# Altium schematic sheet FTDI.SchDoc(FTDI)
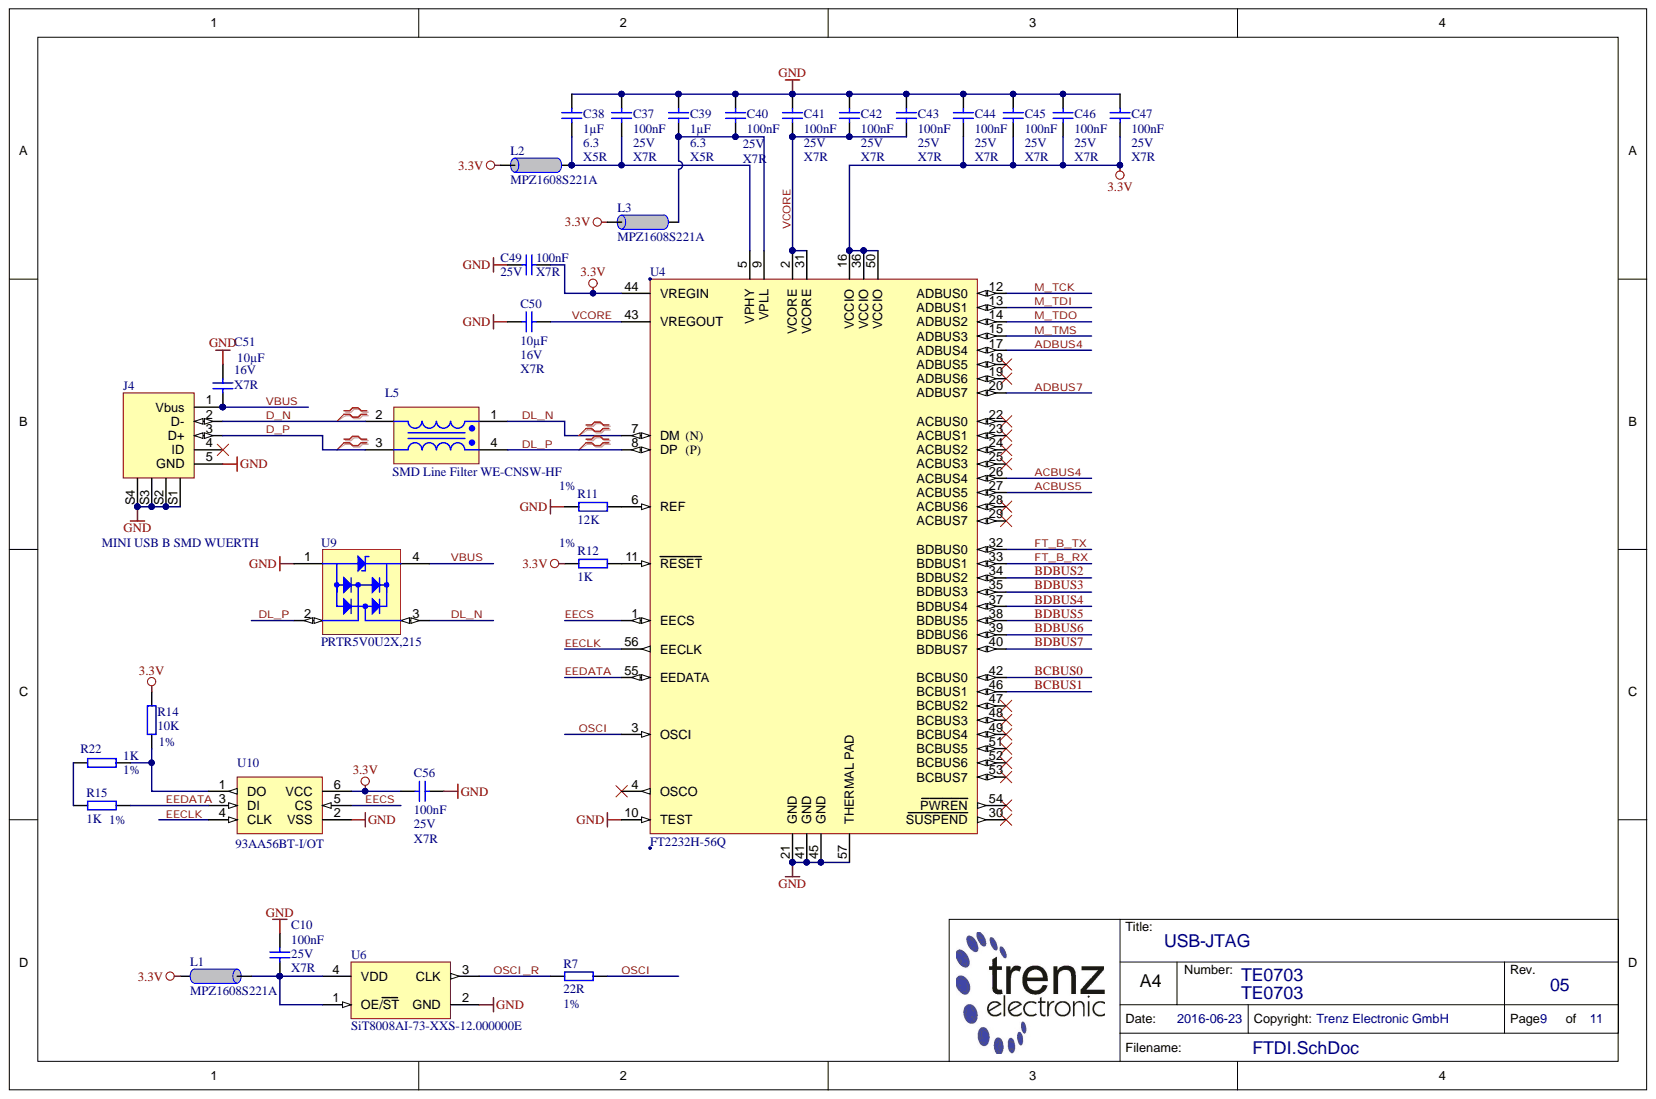
[diagram p0: FTDI.SchDoc]

## Parts
C10  100nF  Technology: X7R  Voltage: 25V  [CAPC1005X55N]
C37  100nF  Technology: X7R  Voltage: 25V  [CAPC1005X55N]
C38  1µF  Voltage: 6.3  [CAPC1005X55N]
C39  1µF  Technology: X5R  Voltage: 6.3  [CAPC1005X55N]
C40  100nF  Technology: X7R  Voltage: 25V  [CAPC1005X55N]
C41  100nF  Technology: X7R  Voltage: 25V  [CAPC1005X55N]
C42  100nF  Technology: X7R  Voltage: 25V  [CAPC1005X55N]
C43  100nF  Technology: X7R  Voltage: 25V  [CAPC1005X55N]
C44  100nF  Technology: X7R  Voltage: 25V  [CAPC1005X55N]
C45  100nF  Technology: X7R  Voltage: 25V  [CAPC1005X55N]
C46  100nF  Technology: X7R  Voltage: 25V  [CAPC1005X55N]
C47  100nF  Technology: X7R  Voltage: 25V  [CAPC1005X55N]
C49  100nF  Technology: X7R  Voltage: 25V  [CAPC1005X55N]
C50  10µF  Voltage: 16V  [CAPC2012X135N]
C51  10µF  Technology: X7R  Voltage: 16V  [CAPC2012X135N]
C56  100nF  Voltage: 25V  [CAPC1005X55N]
J4  MINI USB B SMD WUERTH  [MINI USB 5S B TYPE]
L1  MPZ1608S221A  [INDC1608X95N]
L2  MPZ1608S221A  [INDC1608X95N]
L3  MPZ1608S221A  [INDC1608X95N]
L5  SMD Line Filter WE-CNSW-HF  [WE-CNSW-HF]
R7  22R  Tolerance: 1%  [RESC1005X40N]
R11  12K  Tolerance: 1%  [RESC1005X40N]
R12  1K  Tolerance: 1%  [RESC1005X40N]
R14  10K  Tolerance: 1%  [RESC1005X40N]
R15  1K  Tolerance: 1%  [RESC1005X40N]
R22  1K  Tolerance: 1%  [RESC1005X40N]
U4  FT2232H-56Q  [QFN50P800X800-57T610X610N]
U6  SiT8008AI-73-XXS-12.000000E  Database Table Name: CLK  [2016]
U9  PRTR5V0U2X,215  [SOT190P229X114-4N]
U10  93AA56BT-I/OT  [SOT95P280X145-6N]

## Nets
3.3V: C44.2 C45.2 C46.2 C47.2 C49.2 C56.1 L1.1 L2.2 L3.2 R12.1 R14.1 U4.16(VCCIO) U4.36(VCCIO) U4.44(VREGIN) U4.50(VCCIO) U10.6(VCC)
GND: C10.2 C37.1 C38.1 C39.1 C40.1 C41.1 C42.1 C43.1 C44.1 C45.1 C46.1 C47.1 C49.1 C50.1 C51.1 C56.2 J4.5(GND) J4.S1 J4.S2 J4.S3 J4.S4 R11.1 U4.10(TEST) U4.21(GND) U4.41(GND) U4.45(GND) U4.57(THERMAL_PAD) U6.2(GND) U9.1 U10.2(VSS)
ACBUS4: U4.26(ACBUS4)
ACBUS5: U4.27(ACBUS5)
ADBUS4: U4.17(ADBUS4)
ADBUS7: U4.20(ADBUS7)
BCBUS0: U4.42(BCBUS0)
BCBUS1: U4.46(BCBUS1)
BDBUS2: U4.34(BDBUS2)
BDBUS3: U4.35(BDBUS3)
BDBUS4: U4.37(BDBUS4)
BDBUS5: U4.38(BDBUS5)
BDBUS6: U4.39(BDBUS6)
BDBUS7: U4.40(BDBUS7)
D_N: J4.2(D-) L5.2
D_P: J4.3(D+) L5.3
DL_N: L5.1 U4.7(DM) U9.3
DL_P: L5.4 U4.8(DP) U9.2
EECLK: U4.56(EECLK) U10.4(CLK)
EECS: U4.1(EECS) U10.5(CS)
EEDATA: R15.1 U4.55(EEDATA) U10.3(DI)
FT_B_RX: U4.33(BDBUS1)
FT_B_TX: U4.32(BDBUS0)
M_TCK: U4.12(ADBUS0)
M_TDI: U4.13(ADBUS1)
M_TDO: U4.14(ADBUS2)
M_TMS: U4.15(ADBUS3)
OSCI: R7.2 U4.3(OSCI)
OSCI_R: R7.1 U6.3(CLK)
VBUS: C51.2 J4.1(Vbus) U9.4
VCORE: C41.2 C42.2 C43.2 C50.2 U4.2(VCORE) U4.31(VCORE) U4.43(VREGOUT)
NetC10_1: C10.1 L1.2 U6.1(OE/ST) U6.4(VDD)
NetC37_2: C37.2 C38.2 L2.1 U4.5(VPHY)
NetC39_2: C39.2 C40.2 L3.1 U4.9(VPLL)
NetR11_2: R11.2 U4.6(REF)
NetR12_2: R12.2 U4.11(RESET)
NetR14_2: R14.2 R22.2 U10.1(DO)
NetR15_2: R15.2 R22.1

Not connected: J4 4(ID); U4 4(OSCO) 18(ADBUS5) 19(ADBUS6) 22(ACBUS0) 23(ACBUS1) 24(ACBUS2) 25(ACBUS3) 28(ACBUS6) 29(ACBUS7) 30(SUSPEND) 47(BCBUS2) 48(BCBUS3) 49(BCBUS4) 51(BCBUS5) 52(BCBUS6) 53(BCBUS7) 54(PWREN)

## Library data of the parts
C10 C37 C40-C47 C49 C56 = 22472: KON 100nF 25V X5R SMD; Database Table Name: C_1005_0402; Mixed: SMT; Preferred: Yes; Value: 100n; AN: 22472; Case: 1005 (0402); Component Kind: Standard; Library Name: TE.DbLib
  C56: Technology: X7R
C38 C39 = 23453: KON 1µF 6.3V X5R SMD 10%; Database Table Name: C_1005_0402; Mixed: SMT; Preferred: Yes; Value: 1u; AN: 23453; Case: 1005 (0402); Component Kind: Standard; Library Name: TE.DbLib; Tolerance: 10%
  C38: Technology: X5R
C50 C51 = 24380: KON 10µF 16V X7R SMD 10%; Database Table Name: C_2012_0805; Mixed: SMT; Preferred: Yes; Value: 10u; AN: 24380; Case: 2012 (0805); Component Kind: Standard; Library Name: TE.DbLib; Tolerance: 10%
  C50: Technology: X7R
J4 = 24295: STE USB MINI B LP Buchse SMD; Database Table Name: CON_IO; H-ART: 65100516121; Mixed: SMT; Trenz-Stock: Yes; AN: 24295; Component Kind: Standard; Library Name: TE.DbLib; HelpURL: B:\AD\adlib\datasheet\65100516121
L1-L3 = 22718: Ferritdurchführung MPZ1608S221A; Database Table Name: L_1608_0603; Mixed: SMT; Preferred: Yes; Value: 220 OHm @ 100MHz; AN: 22718; Case: 1608 (0603); Component Kind: Standard; H-ART: MPZ1608S221A; Library Name: TE.DbLib; Trenz-Stock: Yes; HelpURL: B:\AD\adlib\datasheet\MPZ1608
L5 = 24514: Stromkompensierter SMD Line Filter HF 320mA 0,24Ohm 50V; Database Table Name: L_SMD; H-ART: 744233670; Mixed: SMT; Preferred: Yes; AN: 24514; Component Kind: Standard; Library Name: TE.DbLib; Technology: 0.24Ohm; Trenz-Stock: Yes; Voltage: 320mA; HelpURL: B:\AD\adlib\datasheet\WE-CNSW HF
R7 = 25892: WID SMD 22R 1% 0,063W; Database Table Name: R_1005_0402; Mixed: SMT; Preferred: Yes; Value: 22; AN: 25892; Case: 1005 (0402); Component Kind: Standard; Library Name: TE.DbLib; Technology: TK100
R11 = 22598: WID SMD 12K 1% 0,063W; Database Table Name: R_1005_0402; Mixed: SMT; Preferred: Yes; AN: 22598; Case: 1005 (0402); Component Kind: Standard; Library Name: TE.DbLib; Technology: TK100; Value: 12K
R12 R15 R22 = 22752: WID SMD 1K 1% 0,063W; Database Table Name: R_1005_0402; Mixed: SMT; Preferred: Yes; AN: 22752; Case: 1005 (0402); Component Kind: Standard; Library Name: TE.DbLib; Technology: TK100; Value: 1K
R14 = 22750: WID SMD 10K 1% 0,063W; Database Table Name: R_1005_0402; Mixed: SMT; Preferred: Yes; AN: 22750; Case: 1005 (0402); Component Kind: Standard; Library Name: TE.DbLib; Technology: TK100; Value: 10K
U4 = 27277: Database Table Name: IF; Mixed: SMT; Preferred: Yes; AN: 27277; Case: VQFN56; Component Kind: Standard; Description: FT2232H-56Q; H-ART: FT2232H-56Q; Library Name: TE.DbLib; Trenz-Stock: Yes; HelpURL: B:\AD\adlib\datasheet\FT2232H
U6 = 25325: SiT8008AI-73-XXS-12.000000E MEMS Oszillator 12MHz; Mixed: SMT; Preferred: Yes; Value: 12M; AN: 25325; Case: 2.0  x 1.6mm; Component Kind: Standard; H-ART: SiT8008AI-73-XXS-12.000000E; Library Name: TE.DbLib; Trenz-Stock: Yes; HelpURL: B:\AD\adlib\datasheet\SiT8008
U9 = 24840: Database Table Name: DIODE; Mixed: SMT; Preferred: Yes; AN: 24840; Case: SOT143; Component Kind: Standard; Description: PRTR5V0U2X,215; H-ART: PRTR5V0U2X,215; Library Name: TE.DbLib; HelpURL: B:\AD\adlib\datasheet\CD143A-SR05
U10 = 24730: Database Table Name: EEPROM; Mixed: SMT; Preferred: Yes; AN: 24730; Case: SOT23-6; Component Kind: Standard; Description: 93AA56BT-I/OT; H-ART: 93AA56BT-I/OT; Library Name: TE.DbLib; Trenz-Stock: Yes; HelpURL: B:\AD\adlib\datasheet\93AA56A
